# Altium schematic sheet Tens.SchDoc
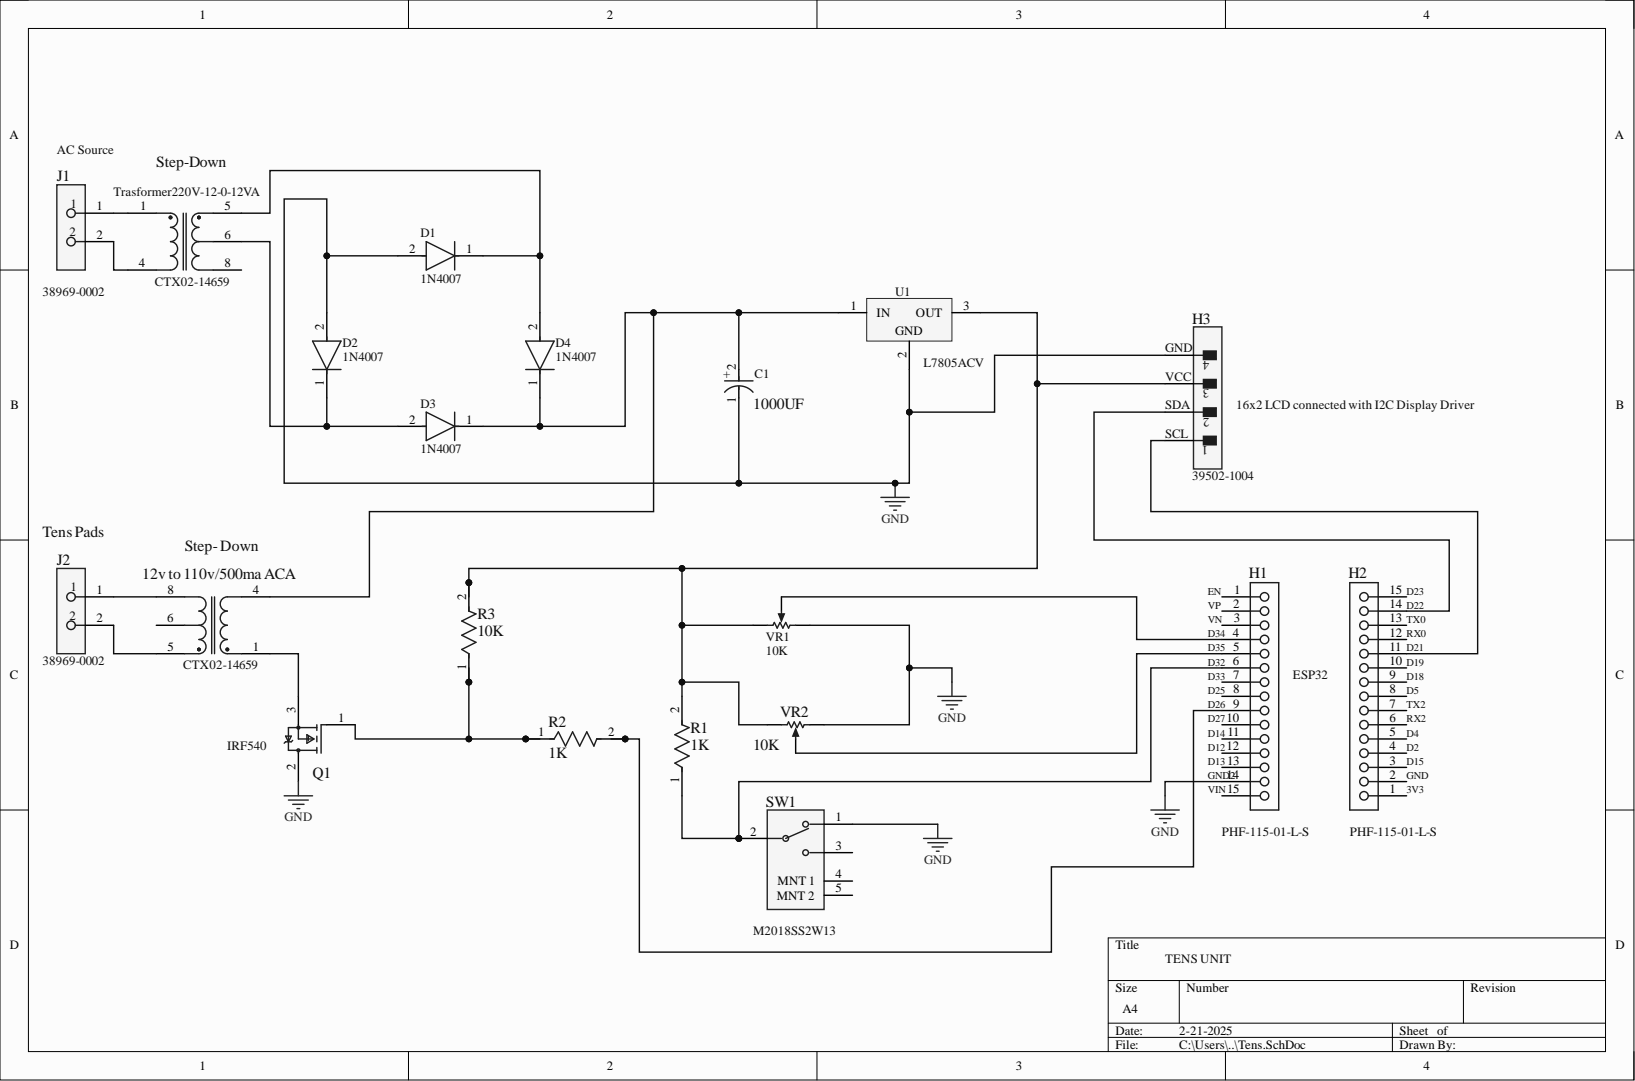
[diagram p0: Tens.SchDoc]

## Parts
12v_to_110v/500ma_AC  CTX02-14659  [EATN-CTX02-14659_V]  (part A)
C1  1000UF  [FP-RAD-TH-D_16_0_5-L_15_1-MFG]
D1  1N4007  [FP-1N4007-MFG]
D2  1N4007  [FP-1N4007-MFG]
D3  1N4007  [FP-1N4007-MFG]
D4  1N4007  [FP-1N4007-MFG]
H1  PHF-115-01-L-S  [SMTC-PHF-115-01-X-S]
H2  PHF-115-01-L-S  [SMTC-PHF-115-01-X-S]
H3  39502-1004  [FP-39502-1004-MFG]
J1  38969-0002  [FP-38969-0002-MFG]
J2  38969-0002  [FP-38969-0002-MFG]
Q1  IRF540  [FP-PG-TO220-3-MFG]
R1  1K  [FP-MRS25-MFG]
R2  1K  [FP-MRS25-MFG]
R3  10K  [FP-MRS25-MFG]
SW1  M2018SS2W13  [FP-M2018SS2W13-MFG]
Trasformer220V-12-0-12V  CTX02-14659  [EATN-CTX02-14659_V]  (part A)
U1  L7805ACV  [TO220]
VR1  10K  [BOUR-3852A-282-103AL-3_V]
VR2  10K  [BOUR-3852A-282-103AL-3_V]

## Nets
GND: C1.1 D1.2 D2.2 H1.14 H3.4 Q1.2 SW1.1 U1.2 VR1.1 VR2.3
Net12v to 110v/500ma AC_1: 12v_to_110v/500ma_AC.1 Q1.3
Net12v to 110v/500ma AC_4: 12v_to_110v/500ma_AC.4 C1.2 D3.1 D4.1 U1.1
Net12v to 110v/500ma AC_5: 12v_to_110v/500ma_AC.5 J2.2
Net12v to 110v/500ma AC_8: 12v_to_110v/500ma_AC.8 J2.1
NetD1_1: D1.1 D4.2 Trasformer220V-12-0-12V.5
NetD2_1: D2.1 D3.2 Trasformer220V-12-0-12V.6
NetH1_4: H1.4 VR1.2
NetH1_5: H1.5 VR2.2
NetH1_6: H1.6 R1.1 SW1.2
NetH1_9: H1.9 R2.2
NetH2_11: H2.11 H3.1
NetH2_14: H2.14 H3.2
NetH3_3: H3.3 R1.2 R3.2 U1.3 VR1.3 VR2.1
NetJ1_1: J1.1 Trasformer220V-12-0-12V.1
NetJ1_2: J1.2 Trasformer220V-12-0-12V.4
NetQ1_1: Q1.1 R2.1 R3.1

Not connected: 12v_to_110v/500ma_AC 6; H1 1 2 3 7 8 10 11 12 13 15; H2 1 2 3 4 5 6 7 8 9 10 12 13 15; SW1 3 4(MNT_1) 5(MNT_2); Trasformer220V-12-0-12V 8

## Library data of the parts
12v_to_110v/500ma_AC Trasformer220V-12-0-12V = CMP-012-00009-3: Transformer Push-Pull, 547 uH, ER 9.5, 8-Pin SMT, RoHS, Tape and Reel; Manufacturer: EATON; Case/Package: SMD; Component Kind: Standard; Datasheet URL: https://datasheet.ciiva.com/36178/1771317-36178918.pdf; Datasheet Version: Rev. H, 09/2006; Mounting Technology: SurfaceMount; Package Description: 8-Pin Surface Mount Technology, Body 10 x 8.1 mm, Pitch 2 mm; Package Reference: CTX02-14659; Pins: 8; RoHS Compliant: True; Library Name: Teja
C1 = CMP-05427-001068-1: Aluminum Electrolytic Capacitors (Radial Lead Type) AEC-Q200 Qualified 1000uF ±20% 35V; Capacitance: 1mF; Dielectric Material: Aluminium; Impedance: 38mR; Lead Diameter: 0.8mm; Life (Hours): 5000Hour; Ripple Current: 1.8A; Case/Package: Radial; Component Kind: Standard; Construction: RADIAL; Diameter: 16mm; Height: 15mm; Lead Pitch: 7.5mm; Library Name: Altium Content Vault; Max Operating Temperature: 105°C; Min Operating Temperature: -55°C; Mount: Through Hole; Number of Pins: 2; Packaging: Bulk; Radiation Hardening: No; REACH SVHC: No SVHC; RoHS Compliant: Yes; Series: FK Radial; Termination: Radial; Tolerance: 20%; Voltage: 35V; Voltage Rating: 35V; Voltage Rating (DC): 35V
D1-D4 = CMP-123542-000001-1: Rectifier diode 1000 V 1 A DO-41, ,; Manufacturer: Diotec; Capacitance: 15pF; Forward Current: 1A; Max Junction Temp: +175°C; Max Repetitive Reverse Voltage: 1000V; Max Surge Forward Current: 27A; Peak Reverse Current: 5uA; Value: 15pF; Automotive: No; Category: Diode; Component Kind: Standard; ComponentLink2Description: Datasheet; ComponentLink2URL: https://datasheet.octopart.com/1N4007-Diotec-datasheet-62346875.pdf; Device Class L1: Discrete Semiconductors; Device Class L2: Diodes; Device Class L3: Rectifier Diodes; Height: 2.8mm; Ipc Land Pattern Name: DIOAD888W100L520D280; Lead Free: Yes; Library Name: Altium Content Vault; Manufacturer Part Number: 1N4007; Octopart: https://octopart.com/1n4007-diotec-4040411; Package: 1N4007; Reverse Voltage: 1000V; RoHS: TRUE; Temperature: -50°C to +175°C; Temperature Range High: +175°C; Temperature Range Low: -50°C
H1 H2 = CMP-1023-00117-1: Press Fit Socket, Through-hole, Vertical, -55 to 125 degC, 2.54 mm Pitch, 15-Pin, Female, RoHS; Manufacturer: Samtec; Pitch: 2.54 mm; Component Kind: Standard; ComponentLink1Description: Manufacturer URL; ComponentLink1URL: http://www.samtec.com; ComponentLink2Description: Datasheet; ComponentLink2URL: http://www.samtec.com/documents/webfiles/pdf/phf.pdf; ComponentLink3Description: Package Specification; ComponentLink3URL: http://www.samtec.com/documents/webfiles/cpdf/PHF-1XX-XX-X-X-XXX-MKT.pdf; DatasheetVersion: F-214; Library Name: Altium Content Vault; Mounting Technology: Through-hole; Orientation: Vertical; PackageDescription: .100 Press Fit Socket Assembly; PackageReference: PHF-115-01-X-S; PackageVersion: F, 9/2009; PartNumber: PHF-115-01-L-S; RoHS: True; Temperature Range: -55 to 125 degC
H3 = CMP-00257-00655381-1: 39502-1004 Barrier Strip Terminal Block, 8A, 1 Row(s), 1 Deck(s); Manufacturer Lifecycle Status: ACTIVE; NexarPartId: 655381; Body Material: Polyamide; Contact Resistance: 8mΩ; Number of Circuits: 4; Pitch: 3.5mm; Voltage Rating: 300V; Color: Black; Component Kind: Standard; Connector Type: Terminal Block; Contact Material: Brass; Contact Plating: Tin; Country of Origin: US; Current Rating: 8A; ELV: Compliant; Flammability Rating: UL94 V-0; Halogen Free: Low Halogen; Housing Color: Black; Housing Material: Nylon; Lead Free: Lead Free; Length: 15.4mm; Library Name: Altium Content Vault; Lifecycle Status: Production; Max Operating Temperature: 105°C; Min Operating Temperature: -40°C; Mount: Through Hole; Number of Contacts: 4; Number of Positions: 4; Number of Rows: 1; Orientation: Horizontal; Packaging: Bulk; REACH SVHC: Unknown; RoHS: Compliant; Schedule B: 8536694040, 8536694040; Termination: Solder; Width: 9.2mm
J1 J2 = CMP-00257-12872391-1: 38969-0002 12.70mm Pitch, Single Row Tri-Barrier Terminal Strip, without Mounting Ends, 2 Circuits; Manufacturer Lifecycle Status: ACTIVE; Connector Type: Terminal Block; Current Rating: 50A; Max Voltage Rating (AC): 600V; Number of Circuits: 2; Pitch: 12.7mm; Wire Gauge (Max): 8AWG; Wire Gauge (Min): 20AWG; Wire/Cable Gauge: 18AWG; Component Kind: Standard; Contact Material: Brass; Contact Plating: Tin; Country of Origin: US; Library Name: Altium Content Vault; Lifecycle Status: Production; Max Operating Temperature: 120°C; Min Operating Temperature: -40°C; Mount: Through Hole; NexarPartId: 12872391; Number of Contacts: 2; Number of Positions: 2; Number of Rows: 1; Orientation: Vertical; REACH SVHC: Unknown; RoHS: Compliant; Termination: Screw; Voltage Rating: 600V
Q1 = CMP-00196-65872481-1: AUIRF540Z Automotive Q101 100V Single N-Channel HEXFET Power MOSFET in a TO-220AB Package; Continuous Drain Current (ID): 36A; Drain to Source Resistance: 21mΩ; Fall Time: 39ns; Gate to Source Voltage (Vgs): 20V; Input Capacitance: 1.77nF; Max Junction Temperature (Tj): 175°C; Max Power Dissipation: 92W; Nominal Vgs: 2V; Number of Channels: 1; On-State Resistance: 26.5mΩ; Rise Time: 51ns; Turn-Off Delay Time: 43ns; Turn-On Delay Time: 15ns; Case/Package: TO-220AB; Component Kind: Standard; Drain to Source Breakdown Voltage: 100V; Drain to Source Voltage (Vdss): 100V; Element Configuration: Single; Height: 16.51mm; Length: 10.66mm; Library Name: Altium Content Vault; Lifecycle Status: Production; Max Operating Temperature: 175°C; Min Operating Temperature: -55°C; Mount: Through Hole; NexarPartId: 65872481; Number of Elements: 1; Number of Pins: 3; Package Quantity: 1000; Packaging: Rail/Tube; Power Dissipation: 92W; Radiation Hardening: No; Rds On Max: 26.5mΩ; REACH SVHC: No SVHC; RoHS: Compliant; Threshold Voltage: 2V; Width: 4.82mm
R1 R2 = CMP-02408-000051-1: RES 1K OHM 0.6W 1% AXIAL; Lead Diameter: 0.6mm; Max Power Dissipation: 600mW; Resistance: 1kR; Temperature Coefficient: 50ppm/°C; Voltage Rating: 350V; Case/Package: Axial; Component Kind: Standard; Construction: Cylindrical; Contact Plating: Tin; Diameter: 2.5mm; Lead Free: Lead Free; Length: 6.5mm; Library Name: Altium Content Vault; Max Operating Temperature: 155°C; Military Standard: Not; Min Operating Temperature: -55°C; Mount: Through Hole; Number of Pins: 2; Number of Terminations: 2; Package Quantity: 5000; Packaging: Tape and Reel; Power Rating: 600mW; Radiation Hardening: No; Resistance Tolerance: 1%; Resistor Type: Metal Film; RoHS Compliant: Yes; Series: MRS25; Technology: THIN FILM; Termination: Axial; Tolerance: 1%; Voltage Rating (DC): 350V; Weight: 0.00776oz; Width: 2.5mm
R3 = CMP-00030-42632392-1: MRS25000C1002FCT00 Resistor, 10 kOhm, ± 1%, 600 mW, Axial Leaded, Metal Film (Thin Film), Professional; Lead Length: 26mm; Max Power Dissipation: 600mW; Resistance: 10kΩ; Temperature Coefficient: 50ppm/°C; Voltage Rating: 350V; Case/Package: Conformal; Component Kind: Standard; Composition: Metal Film, Thin Film; Contact Plating: Tin; Diameter: 2.5mm; Lead Free: Lead Free; Length: 6.5mm; Library Name: Altium Content Vault; Max Operating Temperature: 155°C; Military Standard: Not; Min Operating Temperature: -55°C; Mount: Through Hole; NexarPartId: 42632392; Number of Pins: 2; Number of Terminations: 2; Power Rating: 600mW; Radiation Hardening: No; REACH SVHC: Unknown; RoHS: Compliant; Schedule B: 8533210090, 8533210090; Termination: Axial; Tolerance: 1%; Voltage Rating (DC): 350V; Width: 2.5mm
SW1 = CMP-31749-000001-1: SWITCH TOGGLE SPDT 6A 125V; Actuator Length: 10.5mm; Actuator Material: Brass; Actuator Type: Standard Round; Current Rating: 3A; Electrical Life: 25000Cycles; Illumination Type: Non-Illuminated; Switch Function: Mom-Off-Mom; Voltage Rating (DC): 30V; Component Kind: Standard; Lead Free: Lead Free; Library Name: Altium Content Vault; Max Operating Temperature: 85°C; Min Operating Temperature: -30°C; Mount: Through Hole; Package Quantity: 1; Packaging: Bulk; REACH SVHC: No SVHC; Series: M; Termination: PC Pin; Throw Configuration: SPDT; Voltage Rating (AC): 125V
U1 = CMP-0244-00484-1: Positive Voltage Regulator, 5V, 3-Pin TO-220; Component Kind: Standard; ComponentLink1Description: Manufacturer URL; ComponentLink1URL: http://www.st.com; ComponentLink2Description: Datasheet; ComponentLink2URL: http://www.st.com/internet/com/TECHNICAL_RESOURCES/TECHNICAL_LITERATURE/DATASHEET/CD00000444.pdf; ComponentLink3Description: Package Specification; ComponentLink3URL: http://www.st.com/internet/com/TECHNICAL_RESOURCES/TECHNICAL_LITERATURE/PACKAGE_INFORMATION/CD00004649.pdf; DatasheetVersion: 16/11/2011; Library Name: Altium Content Vault; PackageDescription: TO, Thru-Hole, Vertical, Heatsink Mounted; 3 In-Line Leads; Pitch 2.54 mm; PackageReference: TO220; PackageVersion: ver3.0
VR1 VR2 = CMP-2000-05079-1: Diameter Panel Control, 10 KOhm, +/- 10%, 2 W, -1 to 125 degC, 3-Pin THD, RoHS, Bulk; Manufacturer: Bourns; Actuator Diameter: 0.250"(6.35mm); Actuator Length: 0.875"(22.23mm); Actuator Type: Slotted; Associated Product: 36-8560-ND  -KNOBINSTRUMW/DIALRND.9"DIA  36-8555-ND  -KNOBINSTRUMSKIRTEDRND.7"DIA  36-8556-ND  -KNOBINSTRUMSKIRTEDRND.9"DIA  36-8565-ND  -KNOBINSTRUMDBLBARRND.7"DIA  36-8566-ND  -KNOBINSTRUMDBLBARRND.9"DIA  36-8568-ND  -KNOBINSTRUMSGLBARRND.7"DIA  36-8569-ND  -KNOBINSTRUMSGLBARRND.9"DIA  36-8559-ND  -KNOBINSTRUMW/DIALRND.7"DIA  226-1006-ND  -KNOBBLKGLOSS.75"DIA.250"SHAFT  226-1007-ND  -KNOBBLKGLOSS.925"DIA.250"SHAFT  226-1011-ND  -KNOBBLKGLOS; Built in Switch: None; Catalog Drawings: 3852SeriesSide1    3852SeriesSide2; Number of Gangs: 1; Online Catalog: 3852and3856Series; Other Names: 3852A282103AL; PCN Design/Specification: PBTResinJun/2011; PCN Other: MultipleChanges01/Dec/2012; Power (Watts): 2W; Resistive Material: Cermet; Rotation: 280deg; Temperature Coefficient: ±150ppm/degC; Bushing Thread: 3/8-32; Category: Potentiometers,VariableResistors; Component Kind: Standard; Datasheet URL: https://datasheet.ciiva.com/11831/0900766b80f93b4b-11831016.pdf; Datasheet Version: Sep-14; Family: RotaryPotentiometers,Rheostats; Library Name: Altium Content Vault; Manufacturer URL: http://www.bourns.com/; Mounting Type: PanelMount; Number of Turns: 1; Packaging: Bulk; Resistance (Ohms): 10k; RoHS Information: 3852A-LMaterialDeclaration; Series: 3852; Standard Package: 45; Taper: Linear; Termination Style: SolderLug; Tolerance: ±10%
